# Altium schematic sheet Sheet1.SchDoc
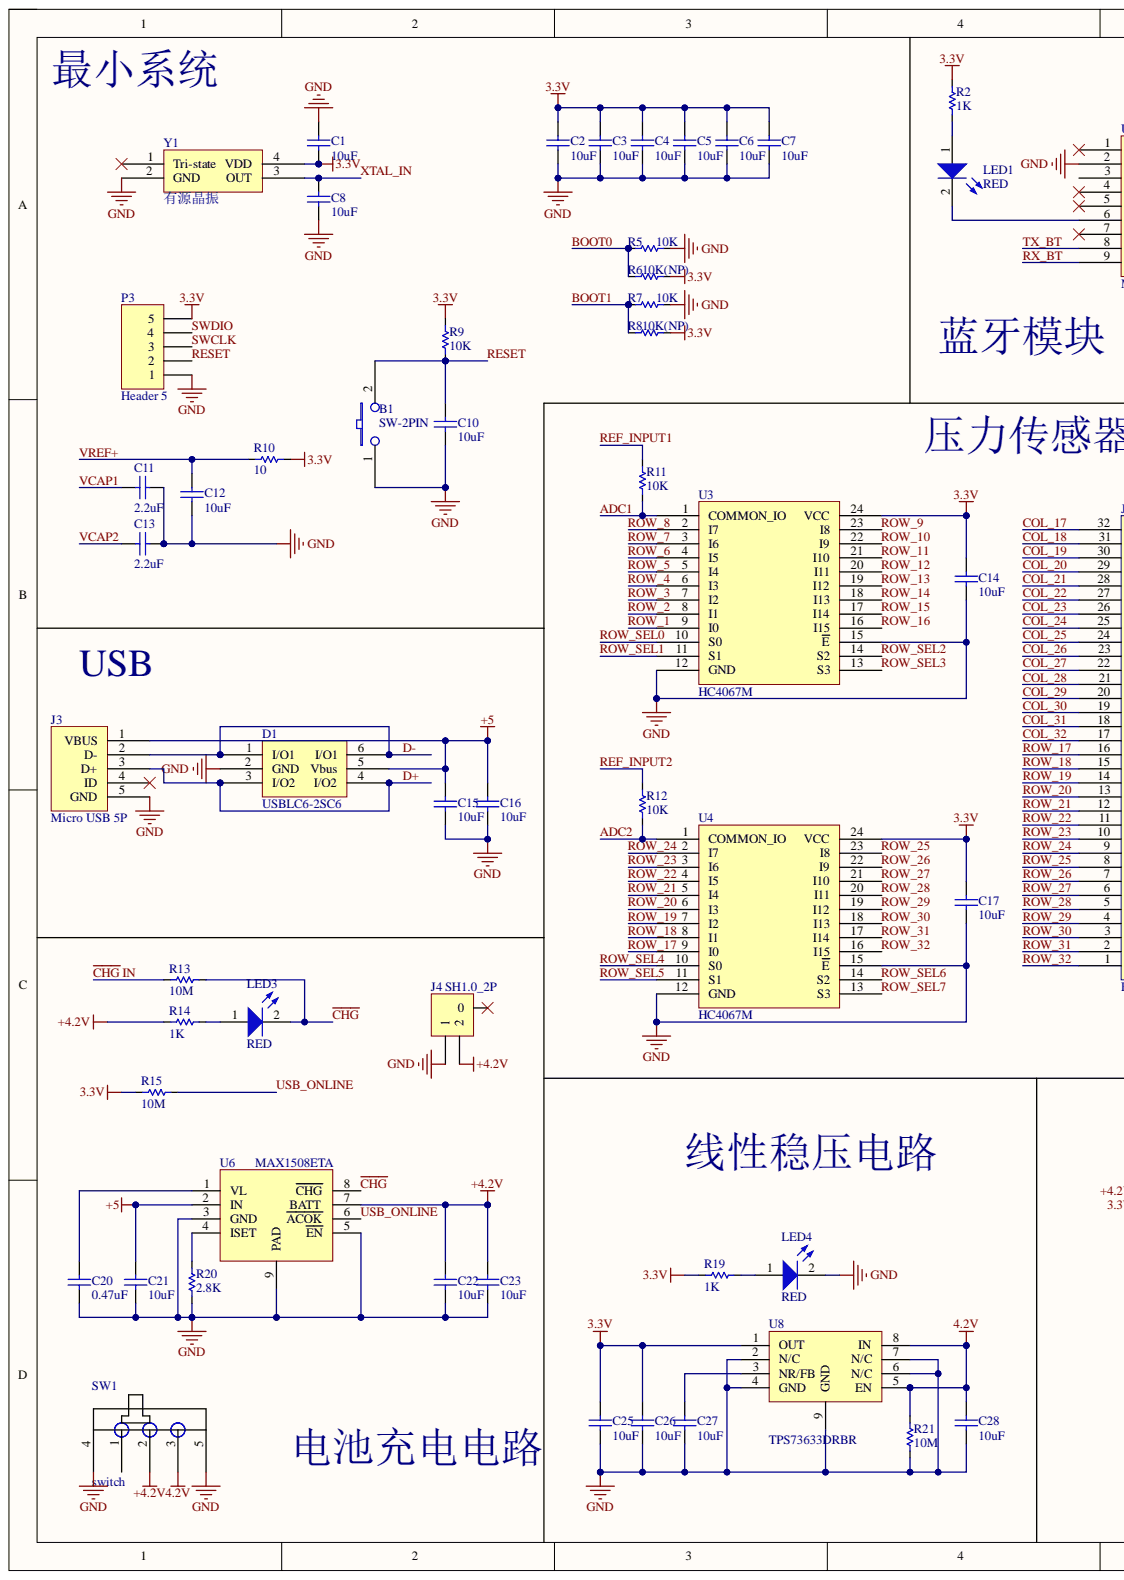
[diagram p0: Sheet1.SchDoc, left]
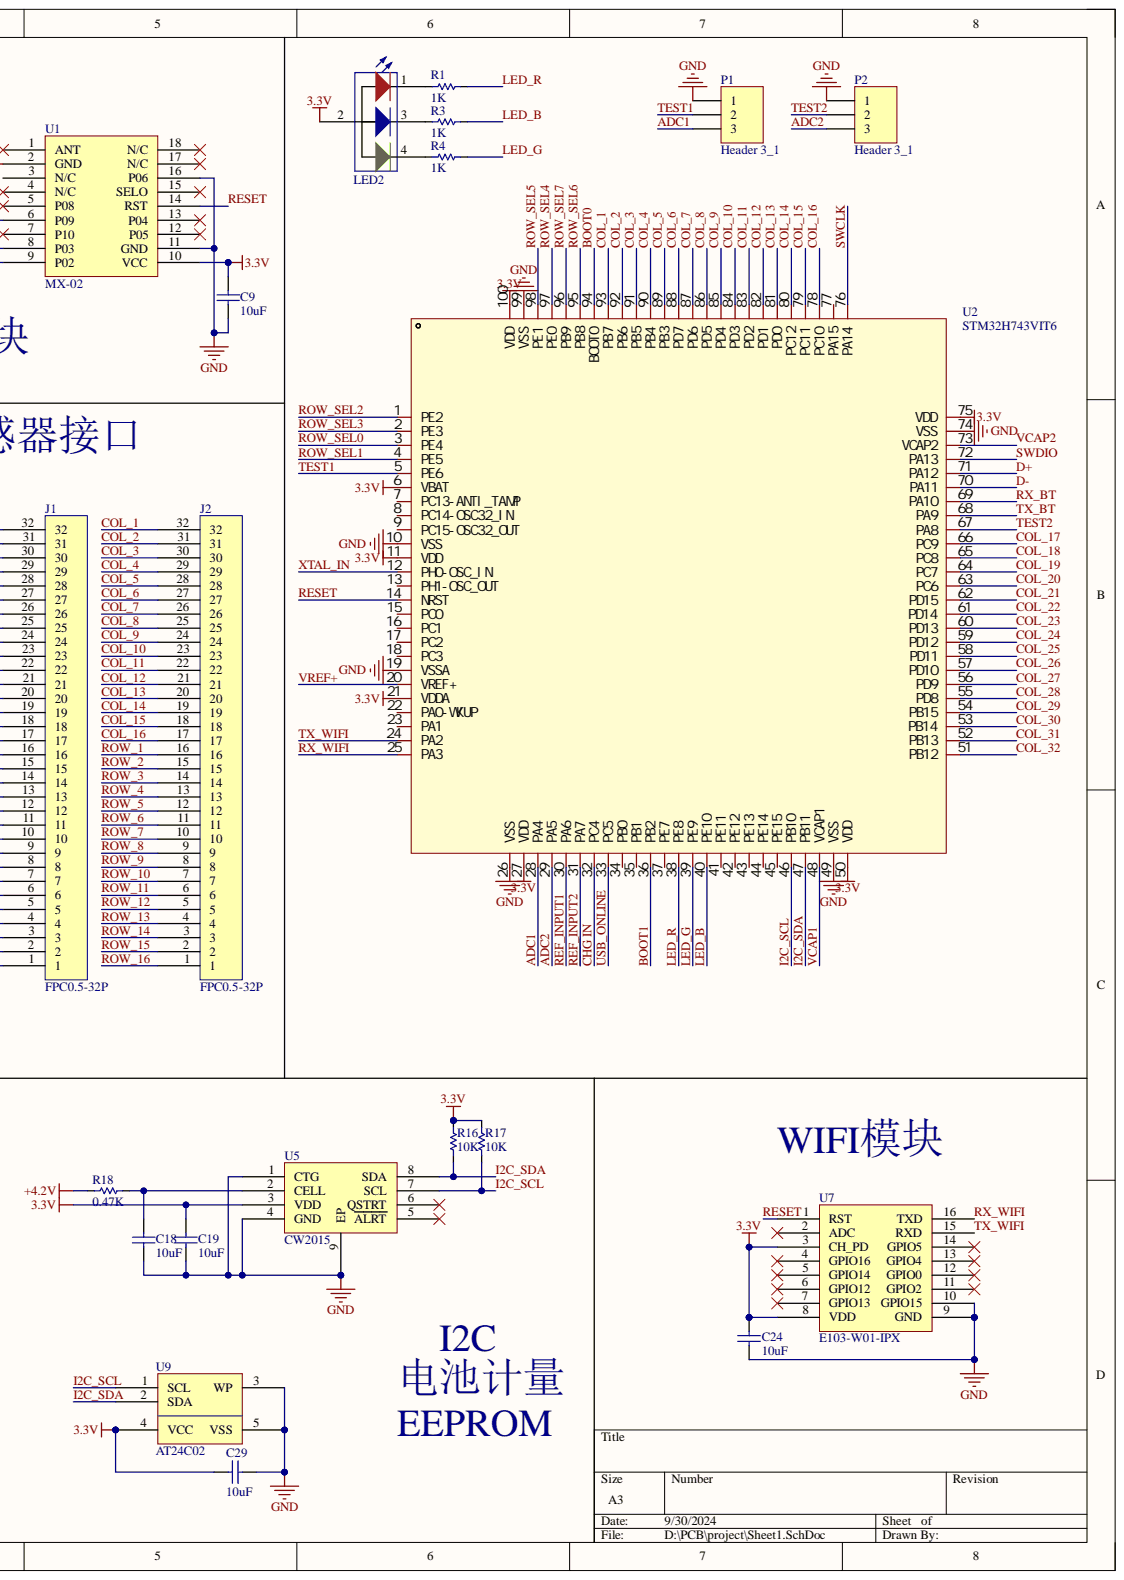
[diagram p1: Sheet1.SchDoc, right]

## Parts
B1  SW-2PIN  [SW-2PIN PUSHBUTTON-3*4*2]
C1  value 10uF  [0603C]  (hidden comment: Cap)
C2  value 10uF  [0603C]  (hidden comment: Cap)
C3  value 10uF  [0603C]  (hidden comment: Cap)
C4  value 10uF  [0603C]  (hidden comment: Cap)
C5  value 10uF  [0603C]  (hidden comment: Cap)
C6  value 10uF  [0603C]  (hidden comment: Cap)
C7  value 10uF  [0603C]  (hidden comment: Cap)
C8  value 10uF  [0603C]  (hidden comment: Cap)
C9  value 10uF  [0603C]  (hidden comment: Cap)
C10  value 10uF  [0603C]  (hidden comment: Cap)
C11  value 2.2uF  [0603C]  (hidden comment: Cap)
C12  value 10uF  [0603C]  (hidden comment: Cap)
C13  value 2.2uF  [0603C]  (hidden comment: Cap)
C14  value 10uF  [0603C]  (hidden comment: Cap)
C15  value 10uF  [0603C]  (hidden comment: Cap)
C16  value 10uF  [0603C]  (hidden comment: Cap)
C17  value 10uF  [0603C]  (hidden comment: Cap)
C18  value 10uF  [0603C]  (hidden comment: Cap)
C19  value 10uF  [0603C]  (hidden comment: Cap)
C20  value 0.47uF  [0603C]  (hidden comment: Cap)
C21  value 10uF  [0603C]  (hidden comment: Cap)
C22  value 10uF  [0603C]  (hidden comment: Cap)
C23  value 10uF  [0603C]  (hidden comment: Cap)
C24  value 10uF  [0603C]  (hidden comment: Cap)
C25  value 10uF  [0603C]  (hidden comment: Cap)
C26  value 10uF  [0603C]  (hidden comment: Cap)
C27  value 10uF  [0603C]  (hidden comment: Cap)
C28  value 10uF  [0603C]  (hidden comment: Cap)
C29  value 10uF  [0603C]  (hidden comment: Cap)
D1  USBLC6-2SC6  [SOT23-6L]
J1  FPC0.5-32P  [FPC-SMD_32P-P0.50_XUNPU_FPC-05F-32PH20]
J2  FPC0.5-32P  [FPC-SMD_32P-P0.50_XUNPU_FPC-05F-32PH20]
J3  Micro USB 5P  [USB-Micro-AB]
J4  SH1.0_2P  [SH1.0_2P]
LED1  LED  Colour: RED  [0603LEDR]
LED2  LED_RGB  [LED1010RGB]  (value not drawn)
LED3  LED  Colour: RED  [0603LEDR]
LED4  LED  Colour: RED  [0603LEDR]
P1  Header 3_1  [HDR1X3]
P2  Header 3_1  [HDR1X3]
P3  Header 5  [HDR1X5]
R1  value 1K  [0603R]  (hidden comment: Res)
R2  value 1K  [0603R]  (hidden comment: Res)
R3  value 1K  [0603R]  (hidden comment: Res)
R4  value 1K  [0603R]  (hidden comment: Res)
R5  value 10K  [0603R]  (hidden comment: Res)
R6  value 10K(NP)  [0603R]  (hidden comment: Res)
R7  value 10K  [0603R]  (hidden comment: Res)
R8  value 10K(NP)  [0603R]  (hidden comment: Res)
R9  value 10K  [0603R]  (hidden comment: Res)
R10  value 10  [0603R]  (hidden comment: Res)
R11  value 10K  [0603R]  (hidden comment: Res)
R12  value 10K  [0603R]  (hidden comment: Res)
R13  value 10M  [0603R]  (hidden comment: Res)
R14  value 1K  [0603R]  (hidden comment: Res)
R15  value 10M  [0603R]  (hidden comment: Res)
R16  value 10K  [0603R]  (hidden comment: Res)
R17  value 10K  [0603R]  (hidden comment: Res)
R18  value 0.47K  [0603R]  (hidden comment: Res)
R19  value 1K  [0603R]  (hidden comment: Res)
R20  value 2.8K  [0603R]  (hidden comment: Res)
R21  value 10M  [0603R]  (hidden comment: Res)
SW1  switch  [SW-TH_SK-12E12-G5]
U1  MX-02  [6621_MBA56A]
U2  STM32H743VIT6  [LQFP-100_L14.0-W14.0-P0.50-LS16.0-BL]
U3  HC4067M  [SOIC-24_L15.4-W7.5-P1.27-LS10.3-BL]
U4  HC4067M  [SOIC-24_L15.4-W7.5-P1.27-LS10.3-BL]
U5  CW2015  [DFN8]
U6  MAX1508ETA  [DFN8_3*3]
U7  E103-W01-IPX  [PE103-W01-IPX]
U8  TPS73633DRBR  [DRB8_3*3]
U9  AT24C02  [SOT23-5L]
Y1  有源晶振  [25M 有源晶振]

## Nets
3.3V: C1.1 C2.2 C3.2 C4.2 C5.2 C6.2 C7.2 C9.2 C14.2 C17.2 C19.2 C24.2 C25.2 C26.2 C29.1 LED2.2 P3.5 R2.2 R6.2 R8.2 R9.2 R10.2 R15.2 R16.2 R17.2 R19.2 U1.10(VCC) U2.6(VBAT) U2.11(VDD) U2.21(VDDA) U2.27(VDD) U2.50(VDD) U2.75(VDD) U2.100(VDD) U3.24(VCC) U4.24(VCC) U5.3(VDD) U7.3(CH_PD) U7.8(VDD) U8.1(OUT) U9.4(VCC) Y1.4(VDD)
4.2V: C22.2 C23.2 C28.2 J4.2 R14.2 R18.2 R21.2 SW1.2 SW1.3 U6.7(BATT) U8.5(EN) U8.8(IN)
+5: C15.2 C16.2 C21.2 D1.5(Vbus) J3.1(VBUS) U6.2(IN)
GND: B1.1 C1.2 C2.1 C3.1 C4.1 C5.1 C6.1 C7.1 C8.1 C9.1 C10.1 C11.2 C12.1 C13.2 C14.1 C15.1 C16.1 C17.1 C18.1 C19.1 C20.1 C21.1 C22.1 C23.1 C24.1 C25.1 C26.1 C27.1 C28.1 C29.2 D1.2(GND) J3.5(GND) J4.1 LED4.2 P1.1 P2.1 P3.1 R5.2 R7.1 R20.1 R21.1 SW1.4 SW1.5 U1.2(GND) U1.11(GND) U1.16(P06) U2.10(VSS) U2.19(VSSA) U2.26(VSS) U2.49(VSS) U2.74(VSS) U2.99(VSS) U3.12(GND) U3.15(E) U4.12(GND) U4.15(E) U5.1(CTG) U5.4(GND) U5.9(EP) U6.3(GND) U6.5(EN) U6.9(PAD) U7.9(GND) U7.10(GPIO15) U8.2(N/C) U8.4(GND) U8.6(N/C) U8.7(N/C) U8.9(GND) U9.3(WP) U9.5(VSS) Y1.2(GND)
ADC1: P1.3 R11.1 U2.28(PA4) U3.1(COMMON_IO)
ADC2: P2.3 R12.1 U2.29(PA5) U4.1(COMMON_IO)
BOOT0: R5.1 R6.1 U2.94(BOOT0)
BOOT1: R7.2 R8.1 U2.36(PB2)
~{CHG}: LED3.2 R13.1 U6.8(CHG)
~{CHG} IN: R13.2 U2.32(PC4)
COL_1: J2.32 U2.93(PB7)
COL_2: J2.31 U2.92(PB6)
COL_3: J2.30 U2.91(PB5)
COL_4: J2.29 U2.90(PB4)
COL_5: J2.28 U2.89(PB3)
COL_6: J2.27 U2.88(PD7)
COL_7: J2.26 U2.87(PD6)
COL_8: J2.25 U2.86(PD5)
COL_9: J2.24 U2.85(PD4)
COL_10: J2.23 U2.84(PD3)
COL_11: J2.22 U2.83(PD2)
COL_12: J2.21 U2.82(PD1)
COL_13: J2.20 U2.81(PD0)
COL_14: J2.19 U2.80(PC12)
COL_15: J2.18 U2.79(PC11)
COL_16: J2.17 U2.78(PC10)
COL_17: J1.32 U2.66(PC9)
COL_18: J1.31 U2.65(PC8)
COL_19: J1.30 U2.64(PC7)
COL_20: J1.29 U2.63(PC6)
COL_21: J1.28 U2.62(PD15)
COL_22: J1.27 U2.61(PD14)
COL_23: J1.26 U2.60(PD13)
COL_24: J1.25 U2.59(PD12)
COL_25: J1.24 U2.58(PD11)
COL_26: J1.23 U2.57(PD10)
COL_27: J1.22 U2.56(PD9)
COL_28: J1.21 U2.55(PD8)
COL_29: J1.20 U2.54(PB15)
COL_30: J1.19 U2.53(PB14)
COL_31: J1.18 U2.52(PB13)
COL_32: J1.17 U2.51(PB12)
D+: D1.3(I/O2) D1.4(I/O2) J3.3(D+) U2.71(PA12)
D-: D1.1(I/O1) D1.6(I/O1) J3.2(D-) U2.70(PA11)
I2C_SCL: R17.1 U2.46(PB10) U5.7(SCL) U9.1(SCL)
I2C_SDA: R16.1 U2.47(PB11) U5.8(SDA) U9.2(SDA)
LED_B: R3.1 U2.40(PE10)
LED_G: R4.1 U2.39(PE9)
LED_R: R1.1 U2.38(PE8)
REF_INPUT1: R11.2 U2.30(PA6)
REF_INPUT2: R12.2 U2.31(PA7)
RESET: B1.2 C10.2 P3.2 R9.1 U1.14(RST) U2.14(NRST) U7.1(RST)
ROW_1: J2.16 U3.9(I0)
ROW_2: J2.15 U3.8(I1)
ROW_3: J2.14 U3.7(I2)
ROW_4: J2.13 U3.6(I3)
ROW_5: J2.12 U3.5(I4)
ROW_6: J2.11 U3.4(I5)
ROW_7: J2.10 U3.3(I6)
ROW_8: J2.9 U3.2(I7)
ROW_9: J2.8 U3.23(I8)
ROW_10: J2.7 U3.22(I9)
ROW_11: J2.6 U3.21(I10)
ROW_12: J2.5 U3.20(I11)
ROW_13: J2.4 U3.19(I12)
ROW_14: J2.3 U3.18(I13)
ROW_15: J2.2 U3.17(I14)
ROW_16: J2.1 U3.16(I15)
ROW_17: J1.16 U4.9(I0)
ROW_18: J1.15 U4.8(I1)
ROW_19: J1.14 U4.7(I2)
ROW_20: J1.13 U4.6(I3)
ROW_21: J1.12 U4.5(I4)
ROW_22: J1.11 U4.4(I5)
ROW_23: J1.10 U4.3(I6)
ROW_24: J1.9 U4.2(I7)
ROW_25: J1.8 U4.23(I8)
ROW_26: J1.7 U4.22(I9)
ROW_27: J1.6 U4.21(I10)
ROW_28: J1.5 U4.20(I11)
ROW_29: J1.4 U4.19(I12)
ROW_30: J1.3 U4.18(I13)
ROW_31: J1.2 U4.17(I14)
ROW_32: J1.1 U4.16(I15)
ROW_SEL0: U2.3(PE4) U3.10(S0)
ROW_SEL1: U2.4(PE5) U3.11(S1)
ROW_SEL2: U2.1(PE2) U3.14(S2)
ROW_SEL3: U2.2(PE3) U3.13(S3)
ROW_SEL4: U2.97(PE0) U4.10(S0)
ROW_SEL5: U2.98(PE1) U4.11(S1)
ROW_SEL6: U2.95(PB8) U4.14(S2)
ROW_SEL7: U2.96(PB9) U4.13(S3)
RX_BT: U1.9(P02) U2.69(PA10)
RX_WIFI: U2.25(PA3) U7.16(TXD)
SWCLK: P3.3 U2.76(PA14)
SWDIO: P3.4 U2.72(PA13)
TEST1: P1.2 U2.5(PE6)
TEST2: P2.2 U2.67(PA8)
TX_BT: U1.8(P03) U2.68(PA9)
TX_WIFI: U2.24(PA2) U7.15(RXD)
USB_ONLINE: R15.1 U2.33(PC5) U6.6(ACOK)
VCAP1: C11.1 U2.48(VCAP1)
VCAP2: C13.1 U2.73(VCAP2)
VREF+: C12.2 R10.1 U2.20(VREF+)
XTAL_IN: C8.2 U2.12(PH0-OSC_IN) Y1.3(OUT)
NetC18_2: C18.2 R18.1 U5.2(CELL)
NetC20_2: C20.2 U6.1(VL)
NetC27_2: C27.2 U8.3(NR/FB)
NetLED1_1: LED1.1 R2.1
NetLED1_2: LED1.2 U1.6(P09)
NetLED2_1: LED2.1 R1.2
NetLED2_3: LED2.3 R3.2
NetLED2_4: LED2.4 R4.2
NetLED3_1: LED3.1 R14.1
NetLED4_1: LED4.1 R19.1
NetR20_2: R20.2 U6.4(ISET)

Not connected: J3 4(ID); J4 0; SW1 1; U1 1(ANT) 3(N/C) 4(N/C) 5(P08) 7(P10) 12(P05) 13(P04) 15(SELO) 17(N/C) 18(N/C); U2 7(PC13-ANTI_TAMP) 8(PC14-OSC32_IN) 9(PC15-OSC32_OUT) 13(PH1-OSC_OUT) 15(PC0) 16(PC1) 17(PC2) 18(PC3) 22(PA0-WKUP) 23(PA1) 34(PB0) 35(PB1) 37(PE7) 41(PE11) 42(PE12) 43(PE13) 44(PE14) 45(PE15) 77(PA15); U5 5(ALRT) 6(QSTRT); U7 2(ADC) 4(GPIO16) 5(GPIO14) 6(GPIO12) 7(GPIO13) 11(GPIO2) 12(GPIO0) 13(GPIO4) 14(GPIO5); Y1 1(Tri-state)

## Library data of the parts
B1 = SW-2PIN PUSHBUTTON: Component Kind: Standard; Description: SW-2PIN PUSHBUTTON; Library Name: CoreControlDevices.SchLib
C1-C29 = Cap: No Polarized  Capacitor(Surface Mount); Component Kind: Standard; Library Name: CoreControlDevices.SchLib
D1 = USBLC6-2SC6: Very low capacitance ESD protection; Component Kind: Standard; Library Name: CoreControlDevices.SchLib
J1 J2 = FPC0.5-32P: 贴片; Component Kind: Standard; Library Name: Schlib1.SchLib
J3 = USB: Component Kind: Standard; Library Name: Schlib1.SchLib
LED1 LED3 LED4 = LED: Typical RED, GREEN, YELLOW, AMBER GaAs LED; Component Kind: Standard; Library Name: CoreControlDevices.SchLib
P1 P2 = Header 3_1: Header, 3-Pin; Component Kind: Standard; LatestRevisionDate: 17-Jul-2002; LatestRevisionNote: Re-released for DXP Platform.; Library Name: CoreControlDevices.SchLib; Publisher: Altium Limited
P3 = Header 5: Header, 5-Pin; Component Kind: Standard; LatestRevisionDate: 17-Jul-2002; LatestRevisionNote: Re-released for DXP Platform.; Library Name: CoreControlDevices.SchLib; Publisher: Altium Limited
R1-R21 = Res: Resistor(Surface Mount); Component Kind: Standard; Library Name: CoreControlDevices.SchLib
SW1 = switch: 拨动开关; Component Kind: Standard; Library Name: Schlib1.SchLib
U1 = MX-02: 蓝牙模块; Component Kind: Standard; Library Name: Schlib1.SchLib
U3 U4 = HC4067M: 4-16多路复用器; Component Kind: Standard; Library Name: Schlib1.SchLib
U5 = CW2015: Low-Cost Fuel Gauge Ic with Low-SOC Alert; Component Kind: Standard; Library Name: CoreControlDevices.SchLib
U6 = MAX1508ETA: Linear Li+ Battery Charger with Integrated Pass FET,  Thermal Regulation, and ACOK in 3mm x 3mm TDFN; Component Kind: Standard; Library Name: Schlib1.SchLib
U7 = E103-W01-IPX: WIFI模块; Component Kind: Standard; Library Name: Schlib1.SchLib
U8 = TPS73633DRBR: CAP-FREE NMOS 400 mA LOW-DROPOUT REGULATORS  WITH REVERSE CURRENT PROTECTION; Component Kind: Standard; Library Name: Schlib1.SchLib
U9 = AT24C02: 外置EEPROM芯片; Component Kind: Standard; Library Name: Schlib1.SchLib
Y1 = 25M 有源晶振 3225: Component Kind: Standard; Description: 25M 有源晶振 3225; Library Name: Schlib1.SchLib
J4 = SH1.0_2P: Component Kind: Standard; Library Name: CoreControlDevices.SchLib
LED2 = LED_RGB: Component Kind: Standard; Description: LED_RGB; Library Name: CoreControlDevices.SchLib
U2 = STM32H743VIT6: Component Kind: Standard; Library Name: Schlib1.SchLib
